# Altium schematic sheet UC20RPIMODULE.SchDoc(UC20RPIMODULE)
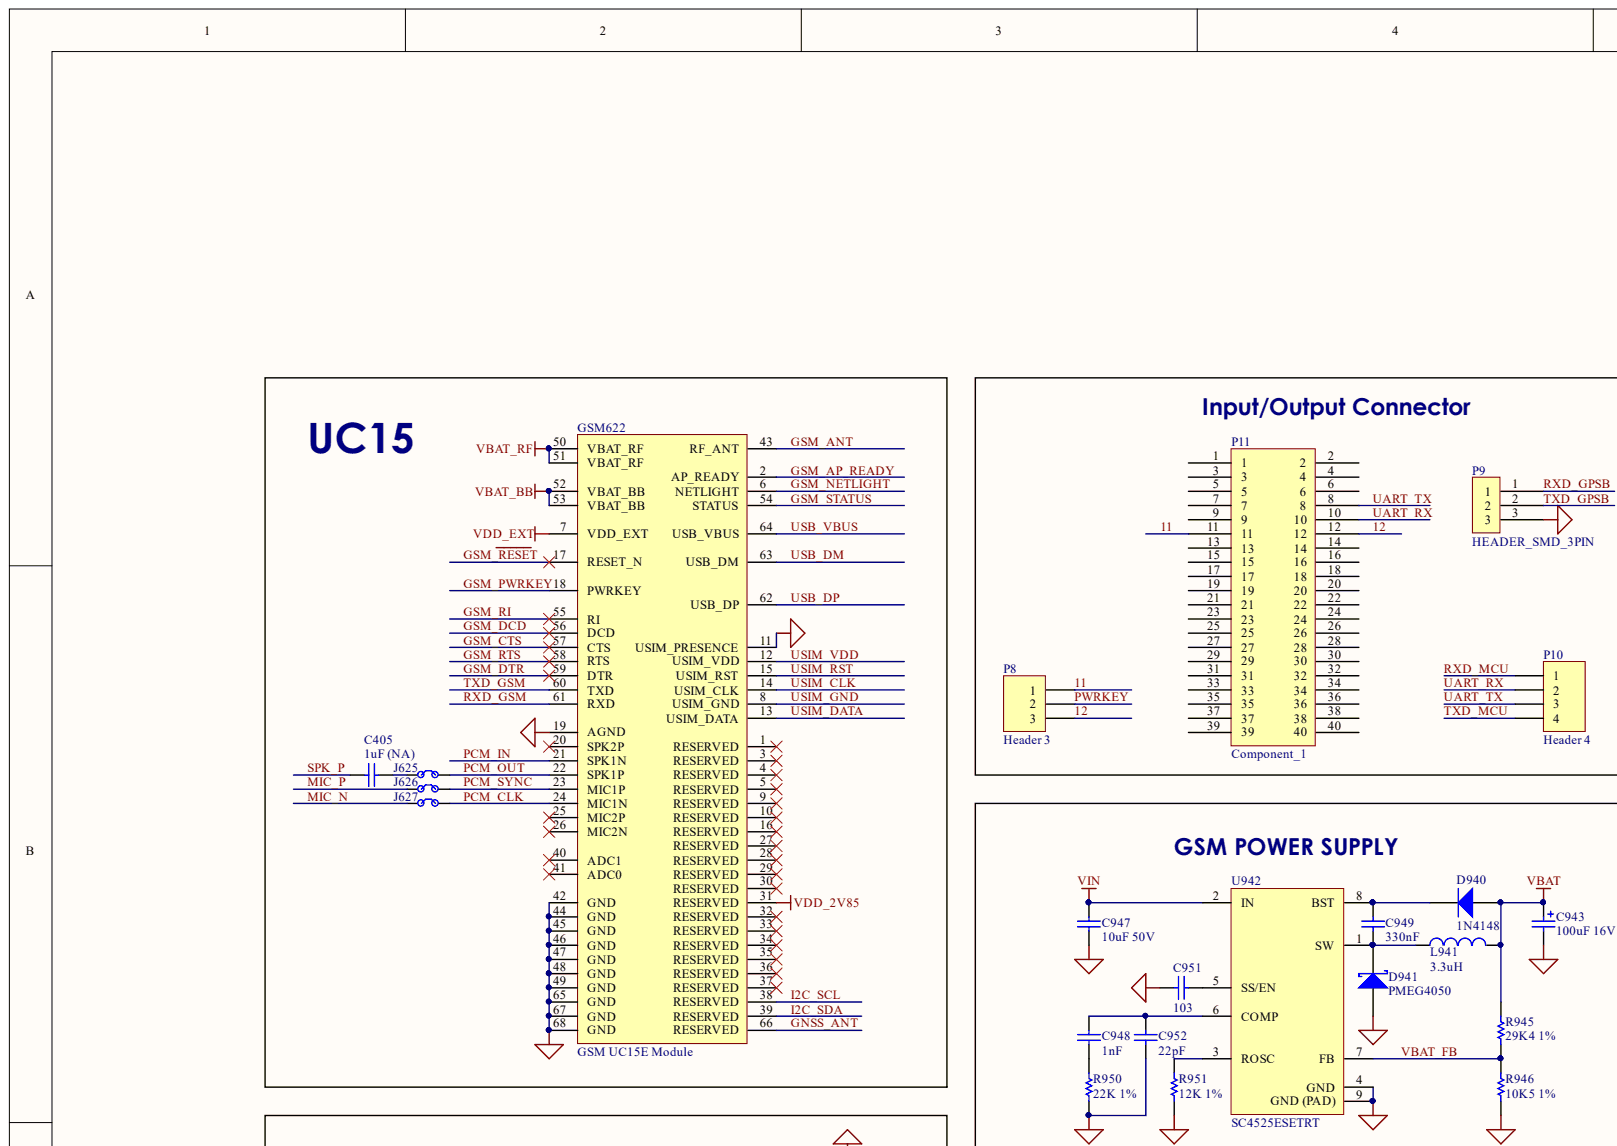
[diagram p0: UC20RPIMODULE.SchDoc, top left]
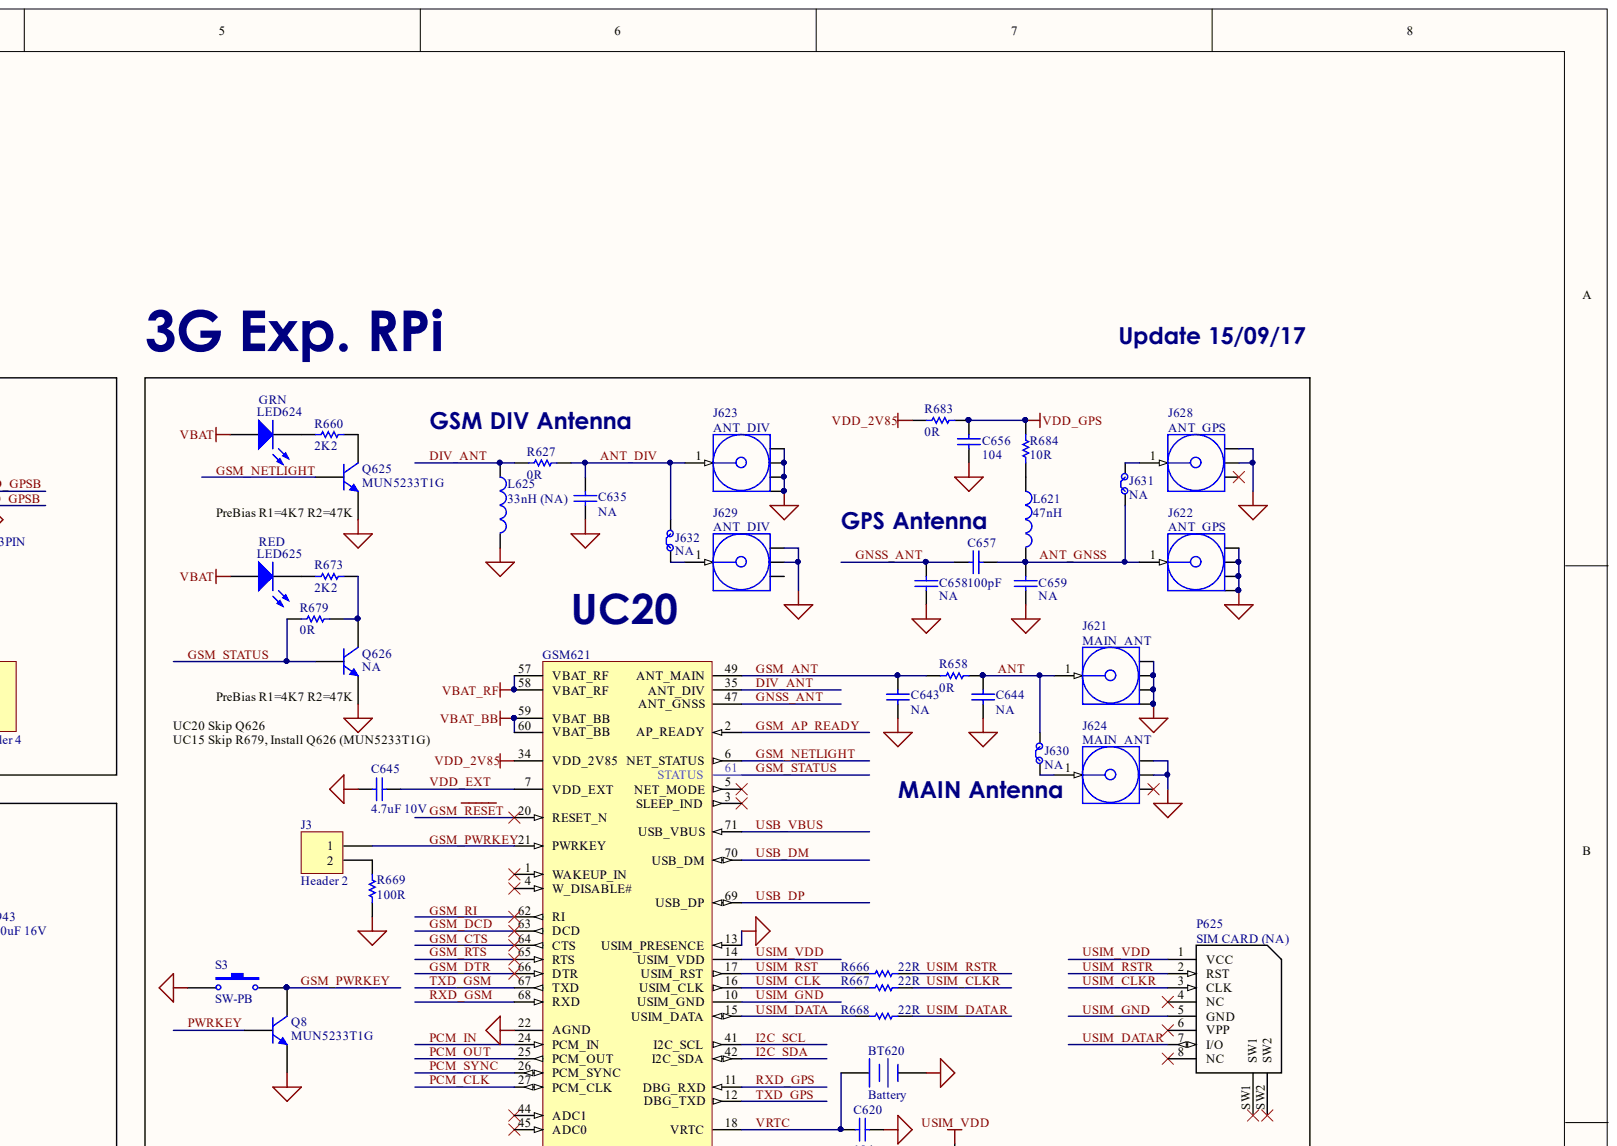
[diagram p1: UC20RPIMODULE.SchDoc, top right]
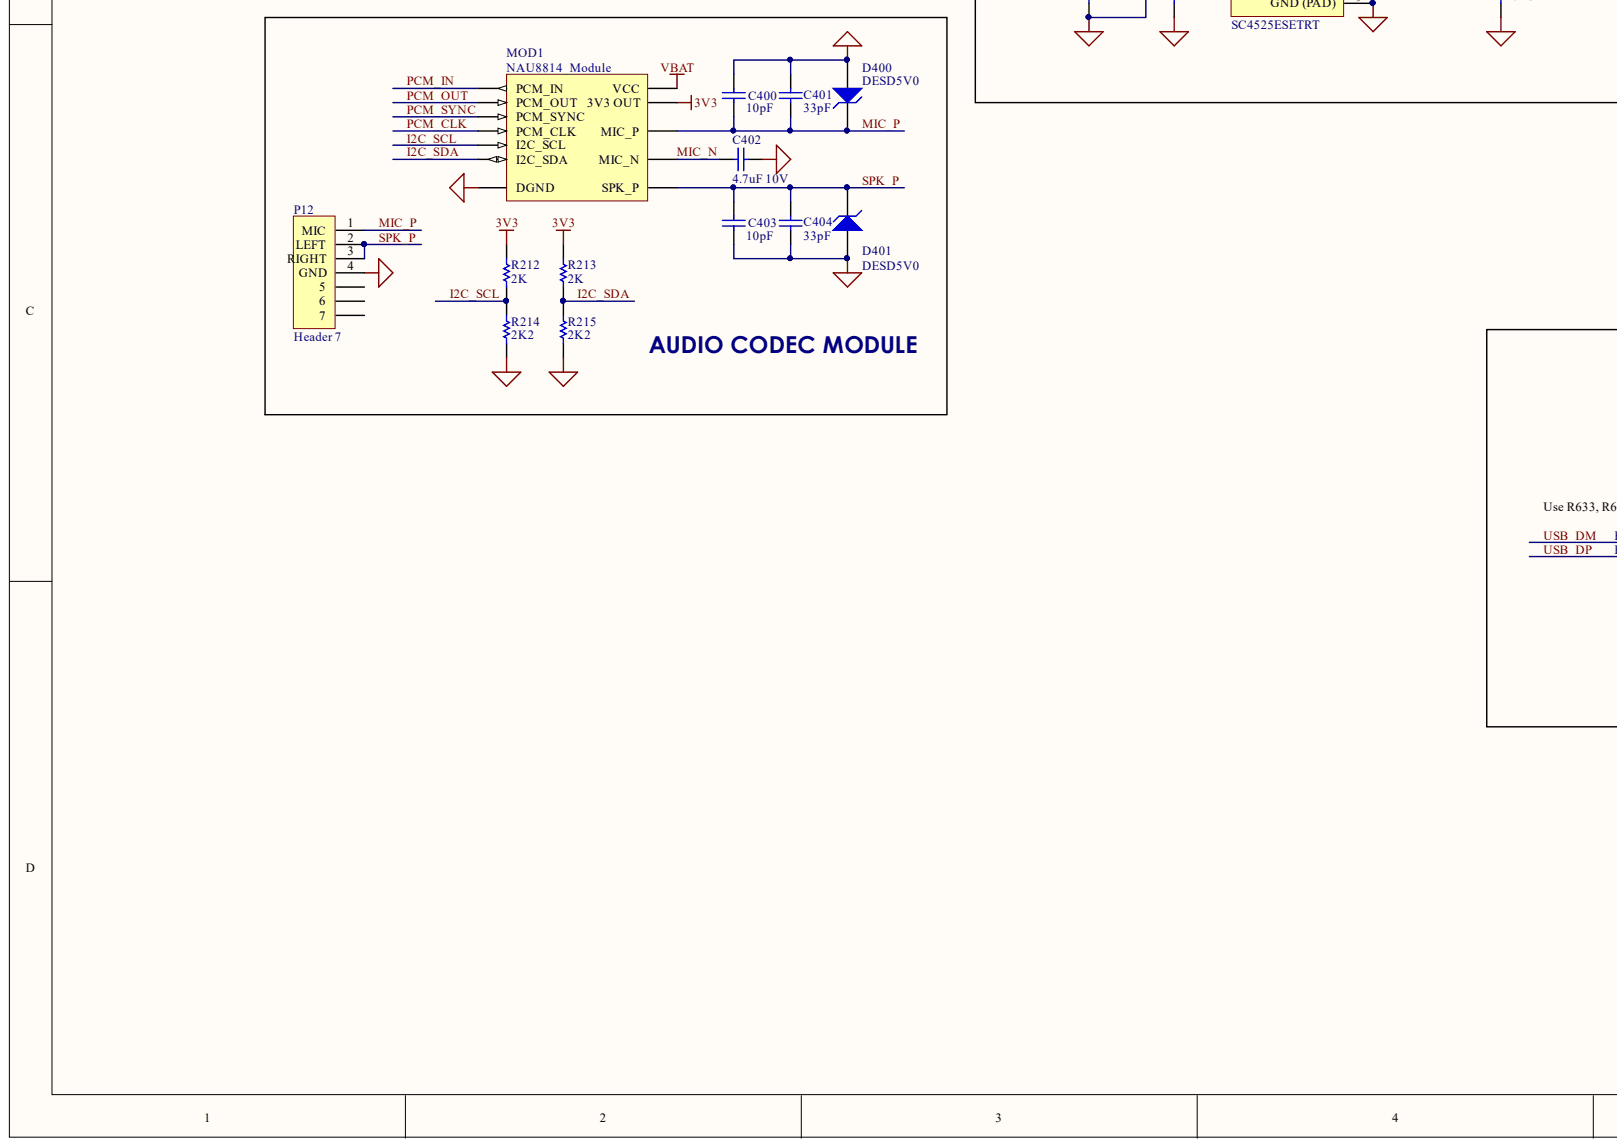
[diagram p2: UC20RPIMODULE.SchDoc, bottom left]
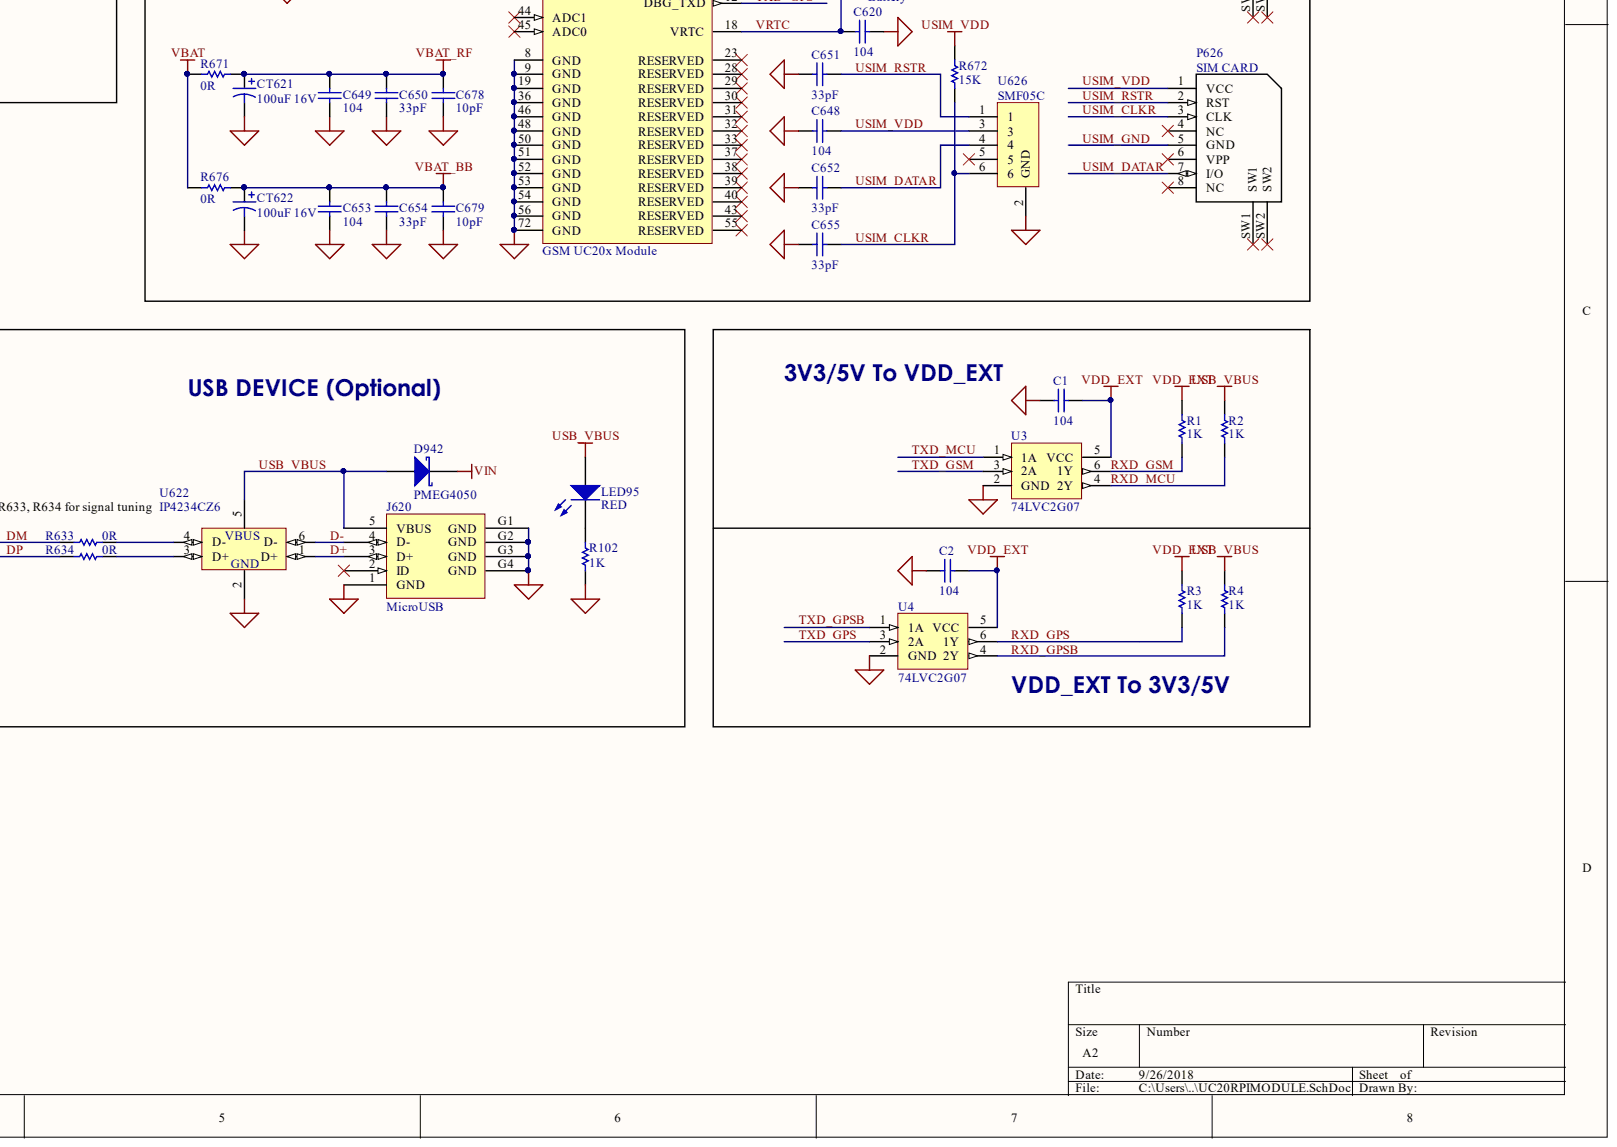
[diagram p3: UC20RPIMODULE.SchDoc, bottom right]

## Parts
BT620  Battery  [BATT_12MM]
C1  104  [IPC7351_EC_0603]
C2  104  [IPC7351_EC_0603]
C400  10pF  [IPC7351_EC_0402]
C401  33pF  [IPC7351_EC_0402]
C402  4.7uF 10V  [IPC7351_EC_0603]
C403  10pF  [IPC7351_EC_0402]
C404  33pF  [IPC7351_EC_0402]
C405  1uF (NA)  [IPC7351_EC_0603]
C620  104  [IPC7351_EC_0603]
C635  NA  [IPC7351_EC_0603]
C643  NA  [IPC7351_EC_0603]
C644  NA  [IPC7351_EC_0603]
C645  4.7uF 10V  [IPC7351_EC_0603]
C648  104  [IPC7351_EC_0603]
C649  104  [IPC7351_EC_0402]
C650  33pF  [IPC7351_EC_0402]
C651  33pF  [IPC7351_EC_0603]
C652  33pF  [IPC7351_EC_0603]
C653  104  [IPC7351_EC_0402]
C654  33pF  [IPC7351_EC_0402]
C655  33pF  [IPC7351_EC_0603]
C656  104  [IPC7351_EC_0603]
C657  100pF  [IPC7351_EC_0603]
C658  NA  [IPC7351_EC_0603]
C659  NA  [IPC7351_EC_0603]
C678  10pF  [IPC7351_EC_0402]
C679  10pF  [IPC7351_EC_0402]
C943  100uF 16V  [IPC7351_EC_1210]
C947  10uF 50V  [IPC7351_EC_1210]
C948  1nF  [IPC7351_EC_0402]
C949  330nF  [IPC7351_EC_0402]
C951  103  [IPC7351_EC_0603]
C952  22pF  [IPC7351_EC_0402]
CT621  100uF 16V  [IPC7351_ECTA_EIA6032]
CT622  100uF 16V  [IPC7351_ECTA_EIA6032]
D400  DESD5V0  [SC76]
D401  DESD5V0  [SC76]
D940  1N4148  [EDIS_SOD-80]
D941  PMEG4050  [EDIS_SMA]
D942  PMEG4050  [EDIS_SMA]
GSM621  GSM UC20x Module  [UC20G]
GSM622  GSM UC15E Module  [UC15E]
J3  Header 2  [HDR1X2]
J620  MicroUSB  [USB_Micro2]
J621  MAIN_ANT  [MCX5.08-H5]
J622  ANT_GPS  [MCX5.08-H5]
J623  ANT_DIV  [MCX5.08-H5]
J624  MAIN_ANT  MFG P/N: NA  [U.FL Connector]
J625  (no value)  [PAD_JUMPER]
J626  (no value)  [PAD_JUMPER]
J627  (no value)  [PAD_JUMPER]
J628  ANT_GPS  MFG P/N: NA  [U.FL Connector]
J629  ANT_DIV  MFG P/N: NA  [U.FL Connector]
J630  NA  [PAD_JUMPER]
J631  NA  [PAD_JUMPER]
J632  NA  [PAD_JUMPER]
L621  47nH  [IPC7351_EL_0603]
L625  33nH (NA)  [IPC7351_EL_0603]
L941  3.3uH  [L_NRS5040 SERIES]
LED95  RED  [IPC7351_ELDS_0603]
LED624  GRN  [IPC7351_ELDS_0603]
LED625  RED  [IPC7351_ELDS_0603]
MOD1  NAU8814_Module  [NAU8814_Module]
P8  Header 3  [HDR1X3]
P9  HEADER_SMD_3PIN  [HEADER_SMD_3PIN]
P10  Header 4  [HDR1X4]
P11  Component_1  [40PIN]
P12  Header 7  [3.5 Jack PJ-3930R 7PIN]
P625  SIM CARD (NA)  [SIM CARD 101-00271-82]
P626  SIM CARD  [SIM CARD 18793]
Q8  MUN5233T1G  [SC-70-3]
Q625  MUN5233T1G  [SC-70-3]
Q626  NA  [SC-70-3]
R1  1K  [IPC7351_ER_0603]
R2  1K  [IPC7351_ER_0603]
R3  1K  [IPC7351_ER_0603]
R4  1K  [IPC7351_ER_0603]
R102  1K  [IPC7351_ER_0603]
R212  2K  [IPC7351_ER_0402]
R213  2K  [IPC7351_ER_0402]
R214  2K2  [IPC7351_ER_0402]
R215  2K2  [IPC7351_ER_0402]
R627  0R  [IPC7351_ER_0603]
R633  0R  [IPC7351_ER_0402]
R634  0R  [IPC7351_ER_0402]
R658  0R  [IPC7351_ER_0603]
R660  2K2  [IPC7351_ER_0603]
R666  22R  [IPC7351_ER_0402]
R667  22R  [IPC7351_ER_0402]
R668  22R  [IPC7351_ER_0402]
R669  100R  [IPC7351_ER_0603]
R671  0R  [IPC7351_ER_0805]
R672  15K  [IPC7351_ER_0603]
R673  2K2  [IPC7351_ER_0603]
R676  0R  [IPC7351_ER_0805]
R679  0R  [IPC7351_ER_0603]
R683  0R  [IPC7351_ER_0603]
R684  10R  [IPC7351_ER_0805]
R945  29K4 1%  [IPC7351_ER_0603]
R946  10K5 1%  [IPC7351_ER_0603]
R950  22K 1%  [IPC7351_ER_0603]
R951  12K 1%  [IPC7351_ER_0603]
S3  SW-PB  [SW_MINI]
U3  74LVC2G07  [SOT363-6M]
U4  74LVC2G07  [SOT363-6M]
U622  IP4234CZ6  [TSOP95P280X110-6N]
U626  SMF05C  [SC-70-6]
U942  SC4525ESETRT  [SOIC127P600-8DN]

## Nets
3V3: MOD1.3V3(3V3_OUT) R212.1 R213.1
DGND: BT620.2 C1.2 C2.2 C400.1 C401.1 C402.2 C403.2 C404.2 C620.2 C635.1 C643.2 C644.2 C645.1 C648.2 C649.2 C650.2 C651.2 C652.2 C653.2 C654.2 C655.2 C656.2 C658.2 C659.2 C678.2 C679.2 C943.2 C947.2 C951.1 C952.1 CT621.2 CT622.2 D400.1 D401.1 D941.1 GSM621.8(GND) GSM621.9(GND) GSM621.13(USIM_PRESENCE) GSM621.19(GND) GSM621.22(AGND) GSM621.36(GND) GSM621.46(GND) GSM621.48(GND) GSM621.50(GND) GSM621.51(GND) GSM621.52(GND) GSM621.53(GND) GSM621.54(GND) GSM621.56(GND) GSM621.72(GND) GSM621.85 GSM621.86 GSM621.87 GSM621.88 GSM621.89 GSM621.90 GSM621.91 GSM621.92 GSM621.93 GSM621.94 GSM621.95 GSM621.96 GSM621.97 GSM621.98 GSM621.99 GSM621.100 GSM621.101 GSM621.102 GSM621.103 GSM621.104 GSM621.105 GSM621.106 GSM621.107 GSM621.108 GSM621.109 GSM621.110 GSM621.111 GSM621.112 GSM622.11(USIM_PRESENCE) GSM622.19(AGND) GSM622.42(GND) GSM622.44(GND) GSM622.45(GND) GSM622.46(GND) GSM622.47(GND) GSM622.48(GND) GSM622.49(GND) GSM622.65(GND) GSM622.67(GND) GSM622.68(GND) GSM622.81 GSM622.82 GSM622.83 GSM622.84 GSM622.85 GSM622.86 GSM622.87 GSM622.88 GSM622.89 GSM622.90 GSM622.91 GSM622.92 GSM622.93 GSM622.94 GSM622.95 GSM622.96 GSM622.97 GSM622.98 GSM622.99 GSM622.100 GSM622.101 GSM622.102 GSM622.103 GSM622.104 GSM622.105 GSM622.106 GSM622.107 GSM622.108 J620.1(GND) J620.G1(GND) J620.G2(GND) J620.G3(GND) J620.G4(GND) J621.2 J621.3 J621.4 J621.5 J622.2 J622.3 J622.4 J622.5 J623.2 J623.3 J623.4 J623.5 J624.2 J624.3 J628.2 J628.3 J629.2 J629.3 L625.2 MOD1.DGND P9.3 P12.4(GND) Q8.2 Q625.2 Q626.2 R102.1 R214.2 R215.2 R669.2 R946.1 R950.1 R951.1 S3.1 U3.2(GND) U4.2(GND) U622.2 U626.2(GND) U942.4(GND) U942.9(GND_(PAD))
VBAT: C943.1 D940.1 L941.2 LED624.1 LED625.1 MOD1.VBAT(VCC) R671.1 R676.1 R945.2
VBAT_BB: C653.1 C654.1 C679.1 CT622.1 GSM621.59(VBAT_BB) GSM621.60(VBAT_BB) GSM622.52(VBAT_BB) GSM622.53(VBAT_BB) R676.2
VBAT_RF: C649.1 C650.1 C678.1 CT621.1 GSM621.57(VBAT_RF) GSM621.58(VBAT_RF) GSM622.50(VBAT_RF) GSM622.51(VBAT_RF) R671.2
VDD_2V85: GSM621.34(VDD_2V85) GSM622.31(RESERVED) R683.1
VDD_GPS: C656.1 R683.2 R684.1
VIN: C947.1 D942.2 U942.2(IN)
11: P8.1 P11.11
12: P8.3 P11.12
ANT: C644.1 J621.1 J630.2 R658.2
ANT_DIV: C635.2 J623.1 J632.1 R627.2
ANT_GNSS: C657.2 C659.1 J622.1 J631.1 L621.2
D+: J620.3(D+) U622.1(GND_D+)
D-: J620.4(D-) U622.6(D-)
DIV_ANT: GSM621.35(ANT_DIV) L625.1 R627.1
GNSS_ANT: C657.1 C658.1 GSM621.47(ANT_GNSS) GSM622.66(RESERVED)
GSM_ANT: C643.1 GSM621.49(ANT_MAIN) GSM622.43(RF_ANT) R658.1
GSM_AP_READY: GSM621.2(AP_READY) GSM622.2(AP_READY)
GSM_CTS: GSM621.64(CTS) GSM622.57(CTS)
GSM_DCD: GSM621.63(DCD) GSM622.56(DCD)
GSM_DTR: GSM621.66(DTR) GSM622.59(DTR)
GSM_NETLIGHT: GSM621.6(NET_STATUS) GSM622.6(NETLIGHT) Q625.1
GSM_PWRKEY: GSM621.21(PWRKEY) GSM622.18(PWRKEY) J3.1 Q8.3 S3.2
GSM_~{RESET}: GSM621.20(RESET_N) GSM622.17(RESET_N)
GSM_RI: GSM621.62(RI) GSM622.55(RI)
GSM_RTS: GSM621.65(RTS) GSM622.58(RTS)
GSM_STATUS: GSM621.61(STATUS) GSM622.54(STATUS) Q626.1 R679.1
I2C_SCL: GSM621.41(I2C_SCL) GSM622.38(RESERVED) MOD1.I2C_SCL R212.2 R214.1
I2C_SDA: GSM621.42(I2C_SDA) GSM622.39(RESERVED) MOD1.I2C_SDA R213.2 R215.1
MIC_N: C402.1 J627.1 MOD1.MIC_N
MIC_P: C400.2 C401.2 D400.2 J626.1 MOD1.MIC_P P12.1(MIC)
PCM_CLK: GSM621.27(PCM_CLK) GSM622.24(MIC1N) J627.2 MOD1.PCM_CLK
PCM_IN: GSM621.24(PCM_IN) GSM622.21(SPK1N) MOD1.PCM_IN
PCM_OUT: GSM621.25(PCM_OUT) GSM622.22(SPK1P) J625.2 MOD1.PCM_OUT
PCM_SYNC: GSM621.26(PCM_SYNC) GSM622.23(MIC1P) J626.2 MOD1.PCM_SYNC
PWRKEY: P8.2 Q8.1
RXD_GPS: GSM621.11(DBG_RXD) R3.1 U4.6(1Y)
RXD_GPSB: P9.1 R4.1 U4.4(2Y)
RXD_GSM: GSM621.68(RXD) GSM622.61(RXD) R1.1 U3.6(1Y)
RXD_MCU: P10.1 R2.1 U3.4(2Y)
SPK_P: C403.1 C404.1 C405.1 D401.2 MOD1.SPK_P P12.2(LEFT) P12.3(RIGHT)
TXD_GPS: GSM621.12(DBG_TXD) U4.3(2A)
TXD_GPSB: P9.2 U4.1(1A)
TXD_GSM: GSM621.67(TXD) GSM622.60(TXD) U3.3(2A)
TXD_MCU: P10.4 U3.1(1A)
UART_RX: P10.2 P11.10
UART_TX: P10.3 P11.8
USB_DM: GSM621.70(USB_DM) GSM622.63(USB_DM) R633.1
USB_DP: GSM621.69(USB_DP) GSM622.62(USB_DP) R634.1
USB_VBUS: D942.1 GSM621.71(USB_VBUS) GSM622.64(USB_VBUS) J620.5(VBUS) LED95.1 R2.2 R4.2 U622.5
USIM_CLK: GSM621.16(USIM_CLK) GSM622.14(USIM_CLK) R667.1
USIM_CLKR: C655.1 P625.3(CLK) P626.3(CLK) R667.2 R672.2 U626.6
USIM_DATA: GSM621.15(USIM_DATA) GSM622.13(USIM_DATA) R668.1
USIM_DATAR: C652.1 P625.7(I/O) P626.7(I/O) R668.2 U626.4
USIM_GND: GSM621.10(USIM_GND) GSM622.8(USIM_GND) P625.5(GND) P626.5(GND)
USIM_RST: GSM621.17(USIM_RST) GSM622.15(USIM_RST) R666.1
USIM_RSTR: C651.1 P625.2(RST) P626.2(RST) R666.2 U626.1
USIM_VDD: C648.1 GSM621.14(USIM_VDD) GSM622.12(USIM_VDD) P625.1(VCC) P626.1(VCC) R672.1 U626.3
VBAT_FB: R945.1 R946.2 U942.7(FB)
VDD_EXT: C1.1 C2.1 C645.2 GSM621.7(VDD_EXT) GSM622.7(VDD_EXT) R1.2 R3.2 U3.5(VCC) U4.5(VCC)
VRTC: BT620.1 C620.1 GSM621.18(VRTC)
NetC405_2: C405.2 J625.1
NetC948_1: C948.1 R950.2
NetC948_2: C948.2 C952.2 U942.6(COMP)
NetC949_1: C949.1 D941.2 L941.1 U942.1(SW)
NetC949_2: C949.2 D940.2 U942.8(BST)
NetC951_2: C951.2 U942.5(SS/EN)
NetJ3_2: J3.2 R669.1
NetJ624_1: J624.1 J630.1
NetJ628_1: J628.1 J631.2
NetJ629_1: J629.1 J632.2
NetL621_1: L621.1 R684.2
NetLED95_2: LED95.2 R102.2
NetLED624_2: LED624.2 R660.2
NetLED625_2: LED625.2 R673.2
NetQ625_3: Q625.3 R660.1
NetQ626_3: Q626.3 R673.1 R679.2
NetR633_2: R633.2 U622.4(D-)
NetR634_2: R634.2 U622.3
NetR951_2: R951.2 U942.3(ROSC)

Not connected: GSM621 1(WAKEUP_IN) 3(SLEEP_IND) 4(W_DISABLE#) 5(NET_MODE) 23(RESERVED) 28(RESERVED) 29(RESERVED) 30(RESERVED) 31(RESERVED) 32(RESERVED) 33(RESERVED) 37(RESERVED) 38(RESERVED) 39(RESERVED) 40(RESERVED) 43(RESERVED) 44(ADC1) 45(ADC0) 55(RESERVED); GSM622 1(RESERVED) 3(RESERVED) 4(RESERVED) 5(RESERVED) 9(RESERVED) 10(RESERVED) 16(RESERVED) 20(SPK2P) 25(MIC2P) 26(MIC2N) 27(RESERVED) 28(RESERVED) 29(RESERVED) 30(RESERVED) 32(RESERVED) 33(RESERVED) 34(RESERVED) 35(RESERVED) 36(RESERVED) 37(RESERVED) 40(ADC1) 41(ADC0); J620 2(ID); J624 4; J628 4; J629 4; P11 1 2 3 4 5 6 7 9 13 14 15 16 17 18 19 20 21 22 23 24 25 26 27 28 29 30 31 32 33 34 35 36 37 38 39 40; P12 5 6 7; P625 4(NC) 6(VPP) 8(NC) SW1 SW2; P626 4(NC) 6(VPP) 8(NC) SW1 SW2; U626 5

## Library data of the parts
BT620 = Battery: Multicell Battery; MFG: AUK CONNECTOR + HUIFENG; MFG P/N: BH1220V-H + CR1220; Component Kind: Standard; Library Name: Miscellaneous Devices.IntLib; Price: 12.04 + 8.8; Supplier: AUK + Jacky
C1 C2 C620 C648 C656 = Cap Semi: Capacitor 0603; MFG: KEMET; MFG P/N: C0603C104J5RACTU; Component Kind: Standard; Library Name: Miscellaneous Devices.IntLib; Price: 0.6; Signal Integrity: Cap Semi; Simulation: CAP; Supplier: ES
C400 C403 C678 C679 = Cap Semi: Capacitor 0402; MFG: KEMET; MFG P/N: C0402C100K5GACTU; Component Kind: Standard; Library Name: Miscellaneous Devices.IntLib; Price: 0.6; Signal Integrity: Cap Semi; Simulation: CAP; Supplier: Digikey
C401 C404 C650 C654 = Cap Semi: Capacitor 0402; MFG: KEMET; MFG P/N: C0402C330J5GACTU; Component Kind: Standard; Library Name: Miscellaneous Devices.IntLib; Price: 0.6; Signal Integrity: Cap Semi; Simulation: CAP; Supplier: Digikey
C402 C645 = Cap Semi: Capacitor 0603; MFG: KEMET; MFG P/N: C0603C475K8PACTU; Component Kind: Standard; Library Name: Miscellaneous Devices.IntLib; Price: 1.45; Signal Integrity: Cap Semi; Simulation: CAP; Supplier: ES
C405 C635 C643 C644 C658 C659 = Cap Semi: Capacitor 0603; Component Kind: Standard; Library Name: Miscellaneous Devices.IntLib; MFG P/N: NA; Signal Integrity: Cap Semi; Simulation: CAP
C649 C653 = Cap Semi: Capacitor 0402; MFG: KEMET; MFG P/N: C0402C104K8RACTU; Component Kind: Standard; Library Name: Miscellaneous Devices.IntLib; Price: 0.6; Signal Integrity: Cap Semi; Simulation: CAP; Supplier: Digikey
C651 C652 C655 = Cap Semi: Capacitor 0603; MFG: KEMET; MFG P/N: C0603C330J5GACTU; Component Kind: Standard; Library Name: Miscellaneous Devices.IntLib; Price: 0.6; Signal Integrity: Cap Semi; Simulation: CAP; Supplier: Digikey
C657 = Cap Semi: Capacitor 0603; MFG: KEMET; MFG P/N: C0603C101J5GACTU; Component Kind: Standard; Library Name: Miscellaneous Devices.IntLib; Price: 0.6; Signal Integrity: Cap Semi; Simulation: CAP; Supplier: Digikey
C943 = Cap Pol2: Capacitor 1210; MFG: TAIYO YUDEN; MFG P/N: EMK325ABJ107MM-T; Component Kind: Standard; Library Name: Miscellaneous Devices.IntLib; Price: 7.28; Signal Integrity: Cap Pol; Simulation: CAP; Supplier: Jacky
C947 = Cap Semi: Capacitor 1210; MFG: MURATA; MFG P/N: GRM32ER61H106KA12L; Component Kind: Standard; Library Name: Miscellaneous Devices.IntLib; Price: 2.85; Signal Integrity: Cap Semi; Simulation: CAP; Supplier: Jacky
C948 = Cap Semi: Capacitor 0402; MFG: KEMET; MFG P/N: C0402C102K5RACTU; Component Kind: Standard; Library Name: Miscellaneous Devices.IntLib; Price: 0.6; Signal Integrity: Cap Semi; Simulation: CAP; Supplier: Digikey
C949 = Cap Semi: Capacitor 0402; MFG: TDK; MFG P/N: C1005X5R1C334K050BC; Component Kind: Standard; Library Name: Miscellaneous Devices.IntLib; Price: 1.59; Signal Integrity: Cap Semi; Simulation: CAP; Supplier: Digikey
C951 = Cap Semi: Capacitor 0603; MFG: KEMET; MFG P/N: C0603C103K5RACTU; Component Kind: Standard; Library Name: Miscellaneous Devices.IntLib; Price: 0.6; Signal Integrity: Cap Semi; Simulation: CAP; Supplier: Digikey
C952 = Cap Semi: Capacitor 0402; MFG: KEMET; MFG P/N: C0402C220K5GACTU; Component Kind: Standard; Library Name: Miscellaneous Devices.IntLib; Price: 0.6; Signal Integrity: Cap Semi; Simulation: CAP; Supplier: Digikey
CT621 CT622 = Cap Pol3: Tantalum Capacitor 6032; MFG: KEMET; MFG P/N: T491C107K016AT; Component Kind: Standard; Library Name: Miscellaneous Devices.IntLib; Price: 5.06; Signal Integrity: Cap Pol; Simulation: CAP; Supplier: Jacky
D400 D401 = D Zener: Zener Diode; MFG: DIODES; MFG P/N: DESD5V0U1BA-7; Component Kind: Standard; Library Name: Miscellaneous Devices.IntLib; Price: 2.18; Signal Integrity: D Zener; Simulation: ZENER; Supplier: Digikey
D940 = Diode: Default Diode; MFG: NXP; MFG P/N: PMLL4148L,115; Component Kind: Standard; Library Name: Miscellaneous Devices.IntLib; Price: 0.4; Signal Integrity: Diode; Simulation: DIODE; Supplier: ES
D941 D942 = D Schottky: Schottky Diode; MFG: NXP; MFG P/N: PMEG4050EP,115; Component Kind: Standard; Library Name: Miscellaneous Devices.IntLib; Price: 6.33; Signal Integrity: D Schottky; Simulation: SKYDIODE; Supplier: Jacky
GSM621 = GSM UC20G: UC20G Module; MFG: QUECTEL; Component Kind: Standard; Library Name: Misc.SchLib; MFG P/N: UC20G; Price: 850; Supplier: Quectel
GSM622 = GSM UC15E: UC15E Module; MFG P/N: NA; Component Kind: Standard; Library Name: Misc.SchLib
J3 = Header 2: Header, 2-Pin; MFG: GTK; MFG P/N: PHSS02G10; Component Kind: Standard; Library Name: Miscellaneous Connectors.IntLib; Price: 0.24; Signal Integrity: Connector; Supplier: ES
J620 = ESOC004: Micro USB Connector; MFG: GTK; MFG P/N: MRUMRB05FTKTY-30U; Component Kind: Standard; Price: 16.44; Supplier: ES; Library Name: ThaiEasySymbol_090120_AD2006.SchLib
J621-J623 = COAX-F: RF Coaxial PCB Connector, MCX; Thru-Hole, Right-Angle Mount Socket, Blunt Post Terminal, 50 Ohm Impedance; MFG: BEYONDOOR; MFG P/N: BY-SMA-7-A; Component Kind: Standard; Library Name: Miscellaneous Connectors.IntLib; Price: 27.81; Supplier: Beyondoor
J624 J628 J629 = COAX-F: RF Coaxial PCB Connector, MCX; Thru-Hole, Right-Angle Mount Socket, Blunt Post Terminal, 50 Ohm Impedance; Component Kind: Standard; Library Name: Miscellaneous Connectors.IntLib
J625-J627 = JUMPER2: Jumper; MFG P/N: NA; Component Kind: Standard; Library Name: Misc.SCHLIB
J630-J632 = JUMPER2: Jumper; Component Kind: Standard; Library Name: Misc.SCHLIB; MFG P/N: NA
L621 = Inductor: Inductor 0603; MFG: MURATA; MFG P/N: LQG18HN47NJ00D; Component Kind: Standard; Library Name: Miscellaneous Devices.IntLib; Price: 7.13; Signal Integrity: Inductor; Simulation: INDUCTOR; Supplier: Digikey
L625 = Inductor: Inductor 0603; Component Kind: Standard; Library Name: Miscellaneous Devices.IntLib; MFG P/N: NA; Signal Integrity: Inductor; Simulation: INDUCTOR
L941 = Inductor: Inductor 3.3 uH; MFG: TAIYO YUDEN; MFG P/N: NRS5040T3R3NMGJ; Component Kind: Standard; Library Name: Miscellaneous Devices.IntLib; Price: 4.75; Signal Integrity: Inductor; Simulation: INDUCTOR; Supplier: ES
LED95 = LED3: GREEN LED 0603; Component Kind: Standard; Library Name: Miscellaneous Devices.IntLib; Simulation: LED3; Supplier1: ES; Supplier1 P/N: LTST-C190KGKT; Supplier1 Price: 1.65
LED624 = LED3: GREEN LED 0603; MFG: LITE-ON; MFG P/N: LTST-C190KGKT; Component Kind: Standard; Library Name: Miscellaneous Devices.IntLib; Price: 1.65; Simulation: LED3; Supplier: ES
LED625 = LED3: RED LED 0603; MFG: LITE-ON; MFG P/N: LTST-C190KRKT; Component Kind: Standard; Library Name: Miscellaneous Devices.IntLib; Price: 1.65; Simulation: LED3; Supplier: ES
P8 = Header 3: Header, 3-Pin; Component Kind: Standard; LatestRevisionDate: 17-Jul-2002; LatestRevisionNote: Re-released for DXP Platform.; Library Name: Miscellaneous Connectors.IntLib; Signal Integrity: Connector; Publisher: Altium Limited
P10 = Header 4: Header, 4-Pin; Component Kind: Standard; LatestRevisionDate: 17-Jul-2002; LatestRevisionNote: Re-released for DXP Platform.; Library Name: Miscellaneous Connectors.IntLib; Signal Integrity: Connector; Publisher: Altium Limited
P12 = Header 7: Header, 7-Pin; Component Kind: Standard; LatestRevisionDate: 17-Jul-2002; LatestRevisionNote: Re-released for DXP Platform.; Library Name: Miscellaneous Connectors.IntLib; Signal Integrity: Connector; Publisher: Altium Limited
P625 = SIM CARD: SIM CARD Socket with Contact Switch; Component Kind: Standard; Library Name: Misc.SchLib; MFG P/N: NA
P626 = SIM CARD: SIM CARD Socket with Contact Switch; MFG: AUK CONNECTOR; MFG P/N: SCPW06L20020-R5H-HCN; Component Kind: Standard; Library Name: Misc.SchLib; Price: 13.26; Supplier: AUK
Q8 Q625 = NPN: NPN Bipolar Transistor; MFG: ON SEMI; Component Kind: Standard; Library Name: Miscellaneous Devices.IntLib; MFG P/N: MUN5233T1G; Price: 1.3; Signal Integrity: NPN; Simulation: NPN; Supplier: Jacky
Q626 = NPN: NPN Bipolar Transistor; Component Kind: Standard; Library Name: Miscellaneous Devices.IntLib; MFG P/N: NA; Signal Integrity: NPN; Simulation: NPN
R1-R4 = Res Semi: Resistor 0603; MFG: ROHM; MFG P/N: MCR03ERTF1001; Component Kind: Standard; Library Name: Miscellaneous Devices.IntLib; Price: 0.4; Signal Integrity: Res Semi; Simulation: RES; Supplier: Digikey
R102 = Res Semi: Resistor 0603; Component Kind: Standard; Library Name: Miscellaneous Devices.IntLib; Signal Integrity: Res Semi; Simulation: RES; Supplier1: Digikey; Supplier1 P/N: MCR03ERTF1001; Supplier1 Price: 0.4
R212 R213 = Res Semi: Resistor 0402; MFG: ROHM; MFG P/N: MCR01MRTF2001; Component Kind: Standard; Library Name: Miscellaneous Devices.IntLib; Price: 0.4; Signal Integrity: Res Semi; Simulation: RES; Supplier: Digikey
R214 R215 = Res Semi: Resistor 0402; MFG: ROHM; MFG P/N: MCR01MRTF2201; Component Kind: Standard; Library Name: Miscellaneous Devices.IntLib; Price: 0.4; Signal Integrity: Res Semi; Simulation: RES; Supplier: Digikey
R627 R658 R679 R683 = Res Semi: Resistor 0603; MFG: ROHM; MFG P/N: MCR03ERTJ000; Component Kind: Standard; Library Name: Miscellaneous Devices.IntLib; Price: 0.4; Signal Integrity: Res Semi; Simulation: RES; Supplier: Digikey
R633 R634 = Res Semi: Resistor 0402; MFG: ROHM; MFG P/N: MCR01MRTJ000; Component Kind: Standard; Library Name: Miscellaneous Devices.IntLib; Price: 0.4; Signal Integrity: Res Semi; Simulation: RES; Supplier: Digikey
R660 R673 = Res Semi: Resistor 0603; MFG: ROHM; MFG P/N: MCR03ERTF2201; Component Kind: Standard; Library Name: Miscellaneous Devices.IntLib; Price: 0.4; Signal Integrity: Res Semi; Simulation: RES; Supplier: Digikey
R666-R668 = Res Semi: Resistor 0402; MFG: ROHM; MFG P/N: MCR01MRTF22R0; Component Kind: Standard; Library Name: Miscellaneous Devices.IntLib; Price: 0.4; Signal Integrity: Res Semi; Simulation: RES; Supplier: Digikey
R669 = Res Semi: Resistor 0603; MFG: ROHM; MFG P/N: MCR03ERTF1000; Component Kind: Standard; Library Name: Miscellaneous Devices.IntLib; Price: 0.4; Signal Integrity: Res Semi; Simulation: RES; Supplier: Digikey
R671 R676 = Res Semi: Resistor 0805; MFG: ROHM; MFG P/N: MCR10ERTJ000; Component Kind: Standard; Library Name: Miscellaneous Devices.IntLib; Price: 0.4; Signal Integrity: Res Semi; Simulation: RES; Supplier: Digikey
R672 = Res Semi: Resistor 0603; MFG: ROHM; MFG P/N: MCR03ERTF1502; Component Kind: Standard; Library Name: Miscellaneous Devices.IntLib; Price: 0.4; Signal Integrity: Res Semi; Simulation: RES; Supplier: Digikey
R684 = Res Semi: Resistor 0805; MFG: ROHM; MFG P/N: MCR10ERTJ100; Component Kind: Standard; Library Name: Miscellaneous Devices.IntLib; Price: 0.4; Signal Integrity: Res Semi; Simulation: RES; Supplier: Digikey
R945 = Res Semi: Resistor 0603; MFG: ROHM; MFG P/N: MCR03ERTF2942; Component Kind: Standard; Library Name: Miscellaneous Devices.IntLib; Price: 0.4; Signal Integrity: Res Semi; Simulation: RES; Supplier: Digikey
R946 = Res Semi: Resistor 0603; MFG: ROHM; MFG P/N: MCR03ERTF1052; Component Kind: Standard; Library Name: Miscellaneous Devices.IntLib; Price: 0.4; Signal Integrity: Res Semi; Simulation: RES; Supplier: Digikey
R950 = Res Semi: Resistor 0603; MFG: ROHM; MFG P/N: MCR03ERTF2202; Component Kind: Standard; Library Name: Miscellaneous Devices.IntLib; Price: 0.4; Signal Integrity: Res Semi; Simulation: RES; Supplier: Digikey
R951 = Res Semi: Resistor 0603; MFG: ROHM; MFG P/N: MCR03ERTF1202; Component Kind: Standard; Library Name: Miscellaneous Devices.IntLib; Price: 0.4; Signal Integrity: Res Semi; Simulation: RES; Supplier: Digikey
S3 = SW-PB: Tact Switch; Component Kind: Standard; Library Name: Miscellaneous Devices.IntLib; Price: 3; Supplier: China
U3 U4 = 74LVC2G07: Dual Buffer/Driver With Open Drain Outputs; MFG: TEXAS; MFG P/N: SN74LVC2G07DCKR; Component Kind: Standard; Library Name: Misc.SchLib; Price: 7.78; Supplier: Digikey
U622 = IP4234CZ6: Single USB 2.0 ESD protection; MFG: NXP; MFG P/N: IP4234CZ6,125; Component Kind: Standard; Library Name: MAX2659.SchLib; Price: 6.45; Supplier: Digikey
U626 = SMF05C: TVS Diode Array For ESD and Latch-Up Protection, SC70-6L; MFG: SEMTECH; MFG P/N: SMF05C.TCT; Component Kind: Standard; Library Name: Misc.SchLib; Price: 1.8; Supplier: Jacky
U942 = SC4525E: 28V 3A Step-Down Switching Regulator; MFG: SEMTECH; Component Kind: Standard; Library Name: Schlib1.SchLib; MFG P/N: SC4525ESETRT; Price: 54.02; Supplier: Digikey
MOD1 = NAU8814_Module: Component Kind: Standard; Description: NAU8814_Module; Library Name: Schlib1.SchLib; MFG P/N: NAU8814_Module; Supplier: Venus
P9 = HEADER_SMD_3PIN: Component Kind: Standard; Library Name: BLOCK_B_SYMBOL.SchLib
P11 = Component_1: Component Kind: Standard; Library Name: 40pinheader.SchLib
